# Altium schematic sheet Flyback.SchDoc
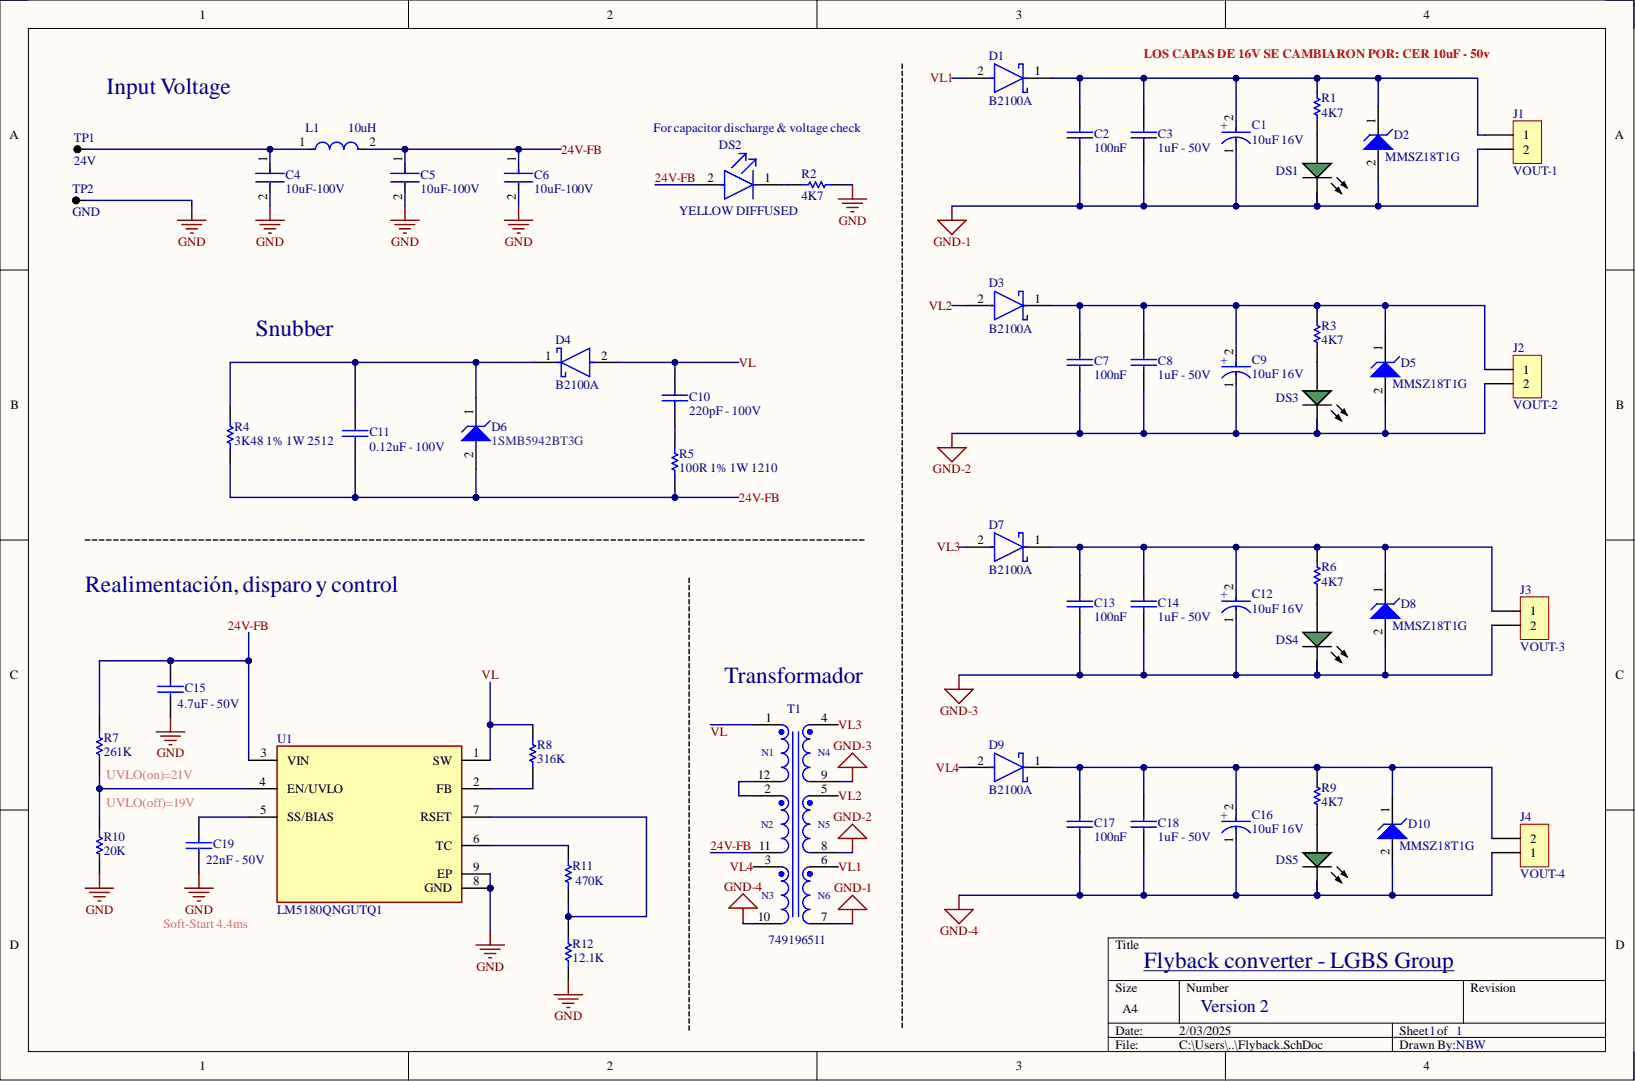
[diagram p0: Flyback.SchDoc]

## Parts
C1  10uF 16V  Capacitance: 10uF  [FP-TAJA-MFG]
C2  100nF  [0805]
C3  1uF - 50V  [0805]
C4  10uF-100V  [FP-GRM32E-0_3-0_2-MFG]
C5  10uF-100V  [FP-GRM32E-0_3-0_2-MFG]
C6  10uF-100V  [FP-GRM32E-0_3-0_2-MFG]
C7  100nF  [0805]
C8  1uF - 50V  [0805]
C9  10uF 16V  Capacitance: 10uF  [FP-TAJA-MFG]
C10  220pF - 100V  [0805]
C11  0.12uF - 100V  [0805]
C12  10uF 16V  Capacitance: 10uF  [FP-TAJA-MFG]
C13  100nF  [0805]
C14  1uF - 50V  [0805]
C15  4.7uF - 50V  [0805]
C16  10uF 16V  Capacitance: 10uF  [FP-TAJA-MFG]
C17  100nF  [0805]
C18  1uF - 50V  [0805]
C19  22nF - 50V  [0805]
D1  B2100A  [FP-SMA-MFG]
D2  MMSZ18T1G  [ONSC-SOD-123-2-425-04_V]
D3  B2100A  [FP-SMA-MFG]
D4  B2100A  [FP-SMA-MFG]
D5  MMSZ18T1G  [ONSC-SOD-123-2-425-04_V]
D6  1SMB5942BT3G  [ONSC-SMB-2-403A-03_H_V]
D7  B2100A  [FP-SMA-MFG]
D8  MMSZ18T1G  [ONSC-SOD-123-2-425-04_V]
D9  B2100A  [FP-SMA-MFG]
D10  MMSZ18T1G  [ONSC-SOD-123-2-425-04_V]
DS1  B1701NG  [AVAG-HSMX-C170_V]  (value not drawn)
DS2  YELLOW DIFFUSED  [FP-LY_R976-MFG]
DS3  B1701NG  [AVAG-HSMX-C170_V]  (value not drawn)
DS4  B1701NG  [AVAG-HSMX-C170_V]  (value not drawn)
DS5  B1701NG  [AVAG-HSMX-C170_V]  (value not drawn)
J1  VOUT-1  [BORNERA2]
J2  VOUT-2  [BORNERA2]
J3  VOUT-3  [BORNERA2]
J4  VOUT-4  [BORNERA2]
L1  10uH  [FP-NR6045-MFG]
R1  4K7  [0805]
R2  4K7  [0805]
R3  4K7  [0805]
R4  3K48 1% 1W 2512  [RESC2512(6432)_M]
R5  100R 1% 1W 1210  [RESC3126X60X50ML10T20]
R6  4K7  [0805]
R7  261K  [0805]
R8  316K  [0805]
R9  4K7  [0805]
R10  20K  [0805]
R11  470K  [0805]
R12  12.1K  [0805]
T1  749196511  [WE-FLEX_EFD20]
TP1  24V  [TPTH]
TP2  GND  [TPTH]
U1  LM5180QNGUTQ1  [FP-NGU0008B-IPC_A]

## Nets
GND: C4.2 C5.2 C6.2 C15.2 C19.2 R2.2 R10.1 R12.1 TP2.1 U1.8(GND) U1.9(EP)
GND-1: C1.1 C2.2 C3.2 D2.2 DS1.2 J1.2 T1.7
GND-2: C7.2 C8.2 C9.1 D5.2 DS3.2 J2.2 T1.8
GND-3: C12.1 C13.2 C14.2 D8.2 DS4.2 J3.2 T1.9
GND-4: C16.1 C17.2 C18.2 D10.2 DS5.2 J4.1 T1.10
24V-FB: C5.1 C6.1 C11.2 C15.1 D6.2 DS2.2 L1.2 R4.1 R5.1 R7.2 T1.11 U1.3(VIN)
VL: C10.1 D4.2 R8.1 T1.1 U1.1(SW)
VL1: D1.2 T1.6
VL2: D3.2 T1.5
VL3: D7.2 T1.4
VL4: D9.2 T1.3
NetC1_2: C1.2 C2.1 C3.1 D1.1 D2.1 J1.1 R1.2
NetC4_1: C4.1 L1.1 TP1.1
NetC7_1: C7.1 C8.1 C9.2 D3.1 D5.1 J2.1 R3.2
NetC10_2: C10.2 R5.2
NetC11_1: C11.1 D4.1 D6.1 R4.2
NetC12_2: C12.2 C13.1 C14.1 D7.1 D8.1 J3.1 R6.2
NetC16_2: C16.2 C17.1 C18.1 D9.1 D10.1 J4.2 R9.2
NetC19_1: C19.1 U1.5(SS/BIAS)
NetDS1_1: DS1.1 R1.1
NetDS2_1: DS2.1 R2.1
NetDS3_1: DS3.1 R3.1
NetDS4_1: DS4.1 R6.1
NetDS5_1: DS5.1 R9.1
NetR7_1: R7.1 R10.2 U1.4(EN/UVLO)
NetR8_2: R8.2 U1.2(FB)
NetR11_1: R11.1 R12.2 U1.7(RSET)
NetR11_2: R11.2 U1.6(TC)
NetT1_2: T1.2 T1.12

## Library data of the parts
C1 C9 C12 C16 = CMP-1661-00004-2: 10uF 16V  ±10% 3Ω 1206  SMD Tantalum Capacitor; Dissipation Factor: 8%; ESR (Equivalent Series Resistance): 3R; Leakage Current: 1.6uA; Polarity: Polar; Case Code (Imperial): 1206; Case Code (Metric): 3216; Case/Package: 1206; Component Kind: Standard; Depth: 1.6mm; Dielectric Material: Tantalum; Features: General Purpose; Height: 1.6mm; Height - Seated (Max): 0.071inch; Lead Free: Lead Free; Lead Pitch: 1.1mm; Length: 3.2mm; Library Name: Altium Content Vault; Max Operating Temperature: 125°C; Min Operating Temperature: -55°C; Mount: Surface Mount; Number of Pins: 2; Package Quantity: 1; Packaging: Tape and Reel; Radiation Hardening: No; REACH SVHC: No SVHC; Termination: SMD/SMT; Tolerance: 10%; Voltage: 16V; Voltage Rating: 16V; Voltage Rating (DC): 16V; Width: 1.6mm
C2 C7 C13 C17 = CAP: Cerámico multicapa; Component Kind: Standard; Library Name: LIBEBAL Tobi.IntLib
C3 C8 C10 C11 C14 C15 C18 C19 = CAP: Component Kind: Standard; Library Name: LIBEBAL Tobi.IntLib
C4-C6 = CMP-06035-004185-1: Chip Multilayer Ceramic Capacitors for General Purpose, 1210, 10uF, X7S, 22%, 20%, 100V; Component Kind: Standard; Library Name: Altium Content Vault
D1 D3 D4 D7 D9 = CMP-12790-000072-1: DIODE SCHOTTKY 100V 2A B2100A; Capacitance: 200pF; Element Configuration: Single; Forward Voltage: 500mV; Max Forward Surge Current (Ifsm): 50A; Max Repetitive Reverse Voltage (Vrrm): 20V; Number of Elements: 1; Peak Reverse Current: 500uA; Polarity: Standard; Average Rectified Current: 2A; Case/Package: SMA; Component Kind: Standard; Contact Plating: Tin; Current Rating: 2A; Forward Current: 2A; Height: 2.1mm; Lead Free: Lead Free; Length: 4.6mm; Library Name: Altium Content Vault; Max Operating Temperature: 150°C; Max Reverse Voltage (DC): 20V; Min Operating Temperature: -65°C; Mount: Surface Mount; Number of Pins: 2; Number of Terminals: 2; Output Current: 2A; Package Quantity: 5000; Packaging: Tape and Reel; Peak Non-Repetitive Surge Current: 50A; Radiation Hardening: No; REACH SVHC: No SVHC; Termination: SMD/SMT; Voltage Rating (DC): 20V; Weight: 0.002258oz; Width: 2.92mm
D2 D5 D8 D10 = CMP-1060-00279-1: Zener Voltage Regulator, 500 mW, 2-Pin SOD-123, Pb-Free, Tape and Reel; Manufacturer: On Semiconductor; P Max (W): 0.5; Component Kind: Standard; ComponentLink1Description: Manufacturer URL; ComponentLink1URL: http://www.onsemi.com/; ComponentLink2Description: Datasheet; ComponentLink2URL: http://www.onsemi.com/pub_link/Collateral/MMSZ2V4T1-D.PDF; ComponentLink3Description: Package Specification; ComponentLink3URL: http://www.onsemi.com/pub_link/Collateral/425-04.PDF; DatasheetVersion: Rev. 11; Library Name: Altium Content Vault; Mounting Technology: Surface Mount; PackageDescription: 2-Pin SOD, Body 1.6 x 2.69 mm; PackageReference: SOD-123-2-425-04; PackageVersion: Rev. G, 10/2009; Packing: Tape and Reel; PartNumber: MMSZ18T1G; RoHS: Pb-Free
D6 = CMP-1060-00186-1: 3 W Zener Voltage Regulator, 2-Pin SMB, Pb-Free, Tape and Reel - 51V; Manufacturer: On Semiconductor; VZ Typ (V): 51; Component Kind: Standard; ComponentLink1Description: Manufacturer URL; ComponentLink1URL: http://www.onsemi.com/; ComponentLink2Description: Datasheet; ComponentLink2URL: http://www.onsemi.com/pub_link/Collateral/1SMB5913BT3-D.PDF; ComponentLink3Description: Package Specification; ComponentLink3URL: http://www.onsemi.com/pub_link/Collateral/1SMB5913BT3-D.PDF; DatasheetVersion: Rev. 8; Library Name: Altium Content Vault; Mounting Technology: Surface Mount; PackageDescription: 2-Pin Small Molded Package, J-Bend Lead, Body 4.33 x 3.625 mm; PackageReference: SMB-2-403A-03_H; PackageVersion: Rev. H, 05/2011; Packing: Tape and Reel; PartNumber: 1SMB5942BT3G; RoHS: Pb-Free
DS1 DS3-DS5 = CMP-2000-05062-1: Chip LED, Green, 2.2 V, -40 to 85 degC, 2-Pin SMD; Manufacturer: Avago; Bulb Size: 1.25mmx1.4mm; Dominant Wavelength: 572nm; External Depth: 0.8mm; External Length / Height: 2mm; External Width: 1.25mm; Forward Current If: 20mA; Forward Voltage: 2.2V; Forward Voltage VF Max: 2.6V; LED Type: Standard; Lens Shape: Rectangular; Light Area Height: 1mm; Luminous Intensity: 15mcd; Luminous Intensity Min: 4mcd; No. of Pins: 2; Opto Mounting Orientation: Top; Peak Wavelength: 570nm; PIV Max: 5V; SVHC: NoSVHC(16-Jun-2014); Viewing Angle: 170deg; Component Kind: Standard; Datasheet URL: https://datasheet.ciiva.com/29280/180495-da-01-en-chip-led-hsmg-c170-gelb-gruen-29280971.pdf; Datasheet Version: Oct-08; Dominant Wavelength Typ: 572nm; Forward Current If Max: 20mA; LED Colour: Green; LED Mounting: SMD; Library Name: Altium Content Vault; Luminous Intensity Typ: 15mcd; Manufacturer URL: http://www.avagotech.com/; Mounting Type: SMD; MSL: MSL2A-4weeks; Operating Temperature Max: 85degC; Operating Temperature Min: -30degC; Operating Temperature Range: -30degCto+85degC; Opto Case Style: SMD; Package Description: 2-Pin Surface Mount Device, Body 2 x 1.25 mm, Height 0.8 mm; Package Reference: HSMX-C170; Packaging: CutTape; Peak Forward Current: 20mA; Storage Temperature Max: 85degC; Storage Temperature Min: -40degC; Voltage Vf Typ: 2.2V; Wavelength Typ: 570nm
DS2 = CMP-62016-000040-1: LED YELLOW DIFFUSED 0805 SMD - LY R976-PS-36; Dominant Wavelength: 588nm; Forward Current: 25mA; Forward Voltage: 2.5V; Lens Color: White; Lens Size: 1.25mm; Lens Style: Flat; Luminous Flux: 0.735lm; Luminous Intensity: 280mcd; Number of Elements: 1; Power Dissipation: 65mW; Reverse Voltage: 12V; Test Current: 20mA; Viewing Angle: 160°; Case Code (Imperial): 0805; Case Code (Metric): 2012; Case/Package: 0805; Color: Yellow; Component Kind: Standard; Depth: 1.35mm; Illumination Color: Yellow; Length: 2.1mm; Lens Transparency: Diffused; Library Name: Altium Content Vault; Max Operating Temperature: 85°C; Min Operating Temperature: -30°C; Mount: Surface Mount; Number of LEDs: 1; Number of Pins: 2; Package Quantity: 16000; Packaging: Tape and Reel; Radiation Hardening: No; Width: 1.25mm
J1-J4 = BORNERA 2: Component Kind: Standard; Library Name: LIBEBAL.IntLib
L1 = CMP-14484-001321-1: Shielded Wirewound Inductor 10uH 20% 2.8A 59.8mΩ SMD - NRS6045T100MMGK; Core Material: Ferrite; Current Rating: 2.4A; DC Resistance (DCR): 59.8mR; Max DC Current: 3.1A; Self Resonant Frequency: 12MHz; Test Frequency: 100kHz; Case/Package: Nonstandard; Component Kind: Standard; Depth: 6.2mm; Halogen Free: Halogen Free; Height: 4.5mm; Height - Seated (Max): 0.177inch; Inductance: 10uH; Inductance Tolerance: 20%; Lead Free: Lead Free; Lead Pitch: 4.7mm; Length: 6mm; Library Name: Altium Content Vault; Max Operating Temperature: 125°C; Min Operating Temperature: -25°C; Mount: Surface Mount; Number of Pins: 2; Package Quantity: 1500; Packaging: Tape and Reel; Radiation Hardening: No; Resistor Type: Wirewound; RMS Current (Irms): 2.4A; RoHS Compliant: Yes; Saturation Current: 3.1A; Series: NRS; Shielding: Shielded; Termination: SMD/SMT; Tolerance: 20%; Width: 0.236inch
R1 R3 R6-R12 = RES: Component Kind: Standard; Library Name: LIBEBAL Tobi.IntLib
R2 = RES: 1/8W; Component Kind: Standard; Library Name: LIBEBAL.IntLib
R4 = CMP-1018-00570-1: 3K48 1W 1% 2512 (6432 Metric)  SMD; Case-EIA: 2512; Case-Metric: 6432; Value: 3480.00 Ohm; Component Kind: Standard; Library Name: Altium Content Vault; Power: 1 W; Technology: SMT; Tolerance: 1 %
R5 = CMP-2005-02161-1: 100 Ohms ±1% 1W Chip Resistor 1210 (3225 Metric) Automotive AEC-Q200, Moisture Resistant Thick Film - AC1210FR-7W100RL; Manufacturer: Yageo; Part Number: AC1210FR-071KL; Component Kind: Standard; Library Name: Altium Content Vault
T1 = CMP-1376-00014-2: NexarPartId: 19122451; Current Rating: 1.7A; DC Resistance (DCR): 71mΩ; Inductance: 22.3µH; Isolation Voltage: 500V; Max Frequency: 1MHz; Turns Ratio: 1:1; Case/Package: SMD/SMT; Component Kind: Standard; Depth: 10.8mm; Height: 10.8mm; Height - Seated (Max): 10.8mm; Length: 21mm; Library Name: Altium Content Vault; Max Operating Temperature: 125°C; Min Operating Temperature: -40°C; Mount: Surface Mount; Packaging: Tape & Reel (TR); REACH SVHC: Unknown; RoHS: Compliant; Secondary Current: 1.7A; Width: 29.5mm
TP1 TP2 = TP: Component Kind: Standard; Library Name: LIBEBAL.IntLib
U1 = CMP-04918-000512-1: POWER MANAGEMENT; Component Kind: Standard; Library Name: Altium Content Vault; RoHS Compliant: Yes
